# Altium schematic sheet PMIC1_iMX6.SchDoc
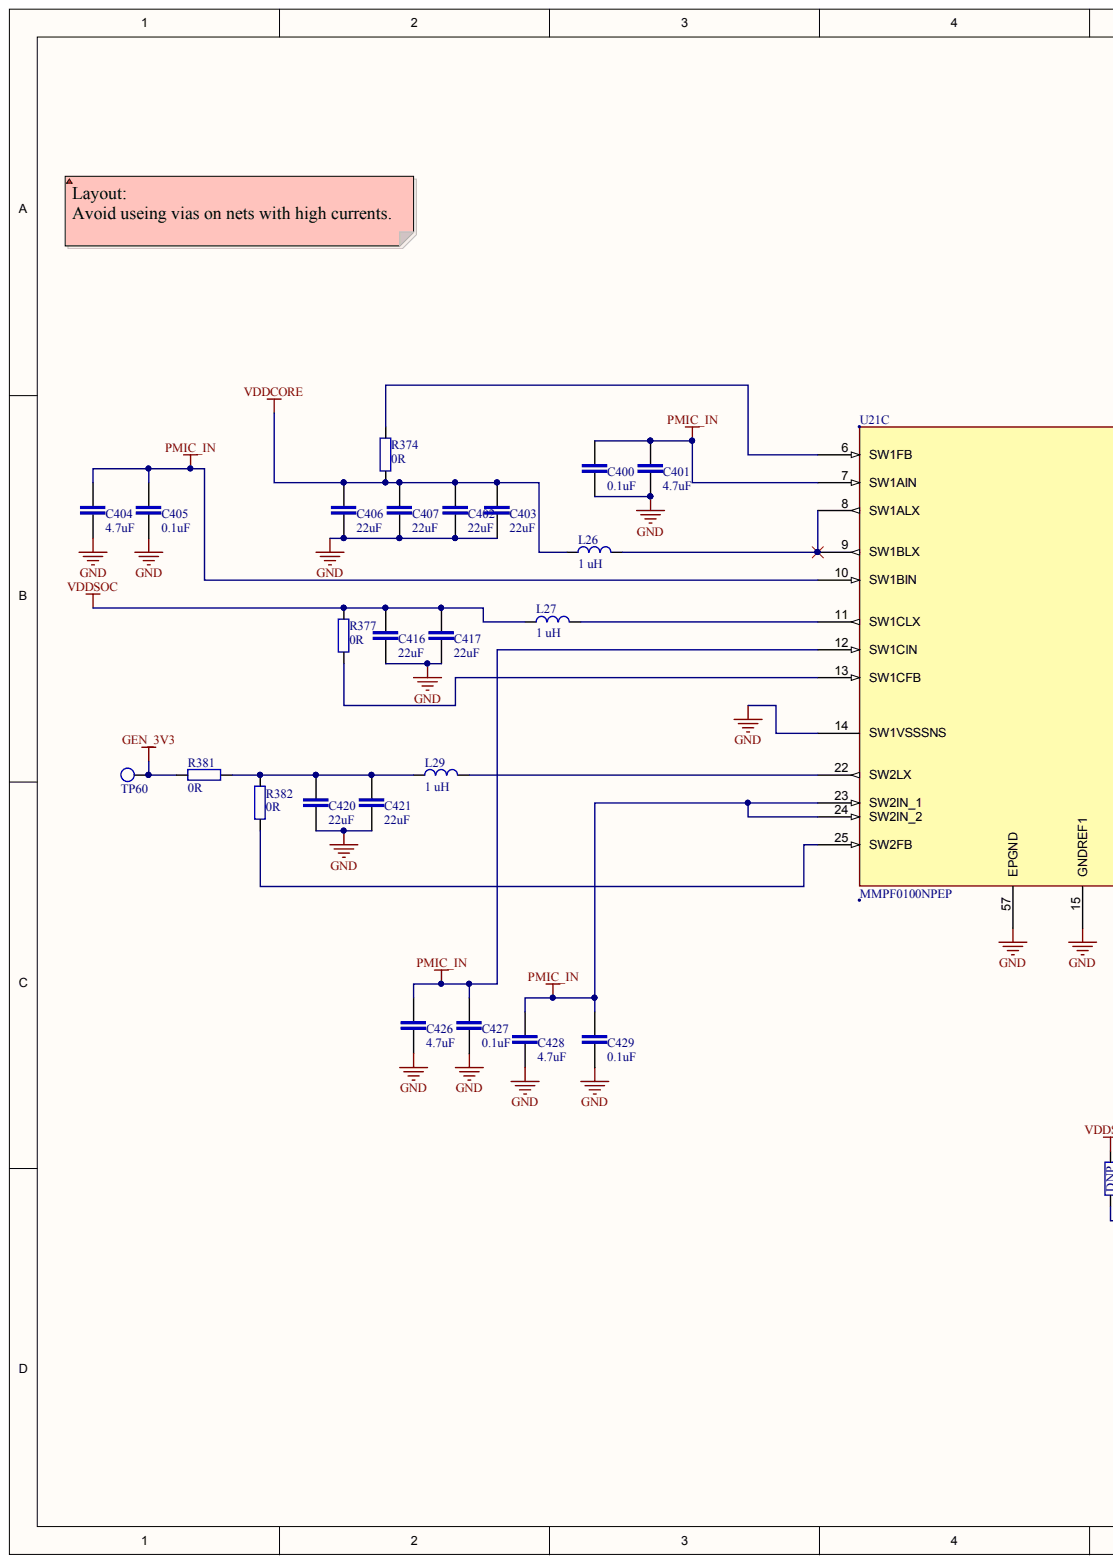
[diagram p0: PMIC1_iMX6.SchDoc, left]
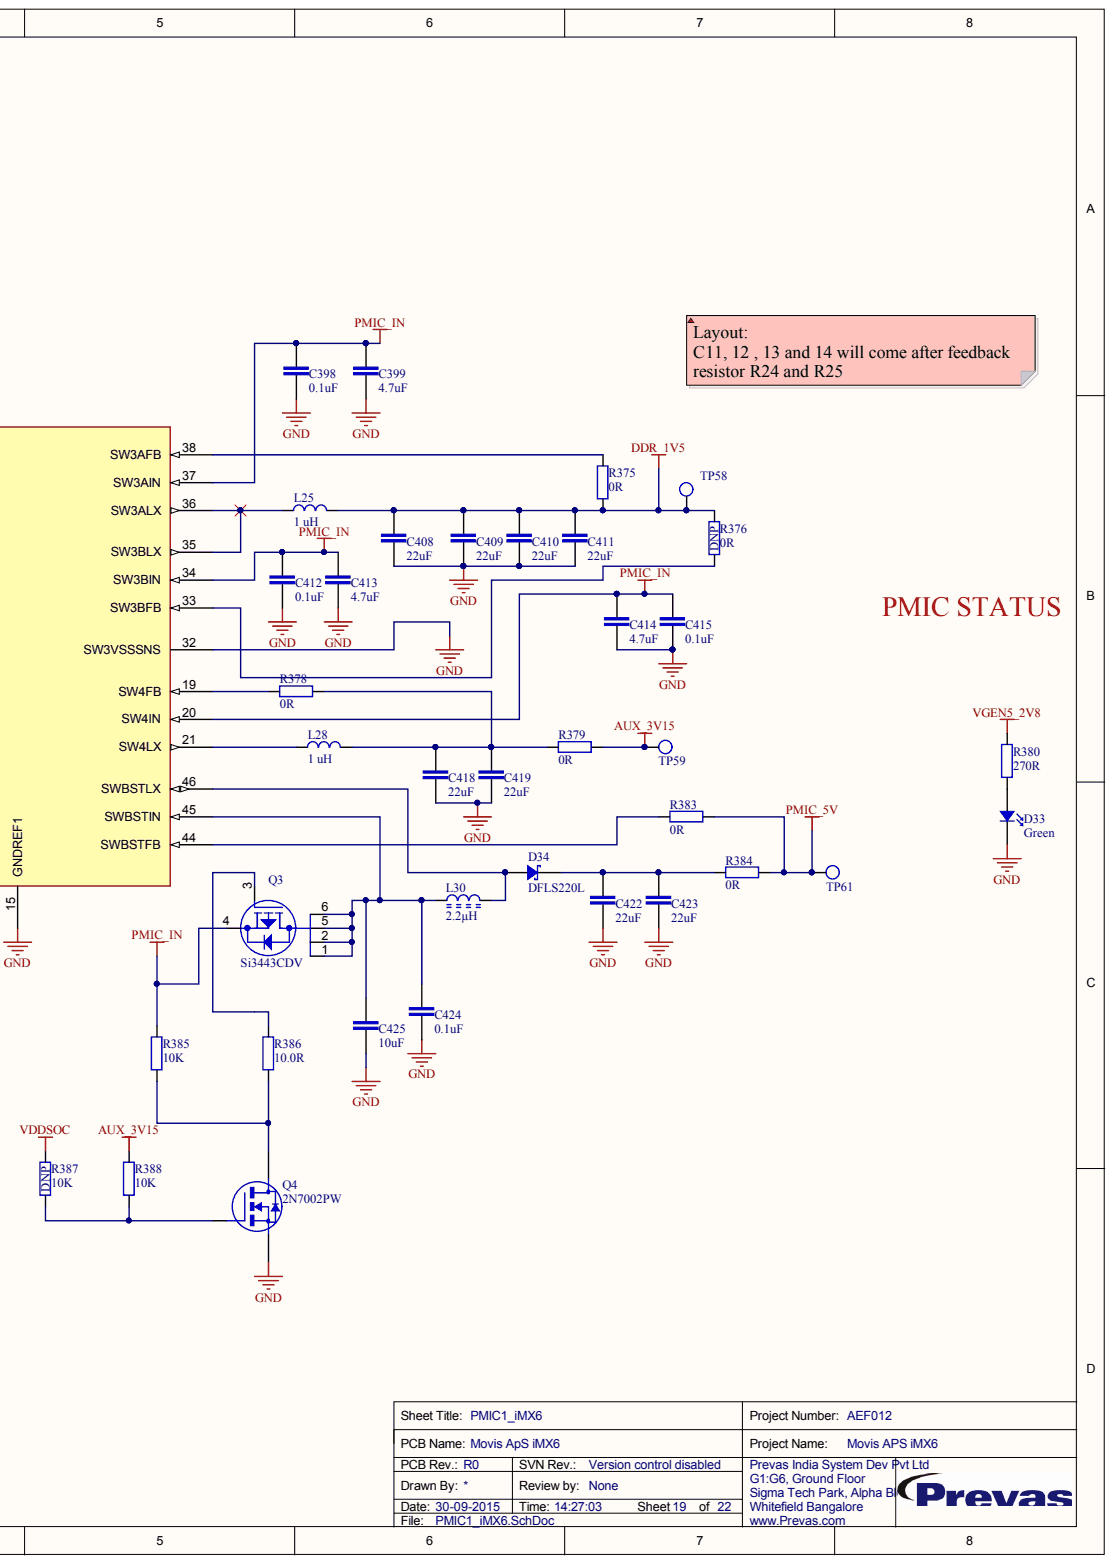
[diagram p1: PMIC1_iMX6.SchDoc, right]

## Parts
C398  0.1uF  [CAPC1005X55N]
C399  4.7uF  [CAPC1608X90N]
C400  0.1uF  [CAPC1005X55N]
C401  4.7uF  [CAPC1608X90N]
C402  22uF  [CAPC3216X180N]
C403  22uF  [CAPC3216X180N]
C404  4.7uF  [CAPC1608X90N]
C405  0.1uF  [CAPC1005X55N]
C406  22uF  [CAPC3216X180N]
C407  22uF  [CAPC3216X180N]
C408  22uF  [CAPC3216X180N]
C409  22uF  [CAPC3216X180N]
C410  22uF  [CAPC3216X180N]
C411  22uF  [CAPC3216X180N]
C412  0.1uF  [CAPC1005X55N]
C413  4.7uF  [CAPC1608X90N]
C414  4.7uF  [CAPC1608X90N]
C415  0.1uF  [CAPC1005X55N]
C416  22uF  [CAPC3216X180N]
C417  22uF  [CAPC3216X180N]
C418  22uF  [CAPC3216X180N]
C419  22uF  [CAPC3216X180N]
C420  22uF  [CAPC3216X180N]
C421  22uF  [CAPC3216X180N]
C422  22uF  [CAPC3216X180N]
C423  22uF  [CAPC3216X180N]
C424  0.1uF  [CAPC1005X55N]
C425  10uF  [CAPC1608X95N]
C426  4.7uF  [CAPC1608X90N]
C427  0.1uF  [CAPC1005X55N]
C428  4.7uF  [CAPC1608X90N]
C429  0.1uF  [CAPC1005X55N]
D33  Green  [LEDC1608X110N-G]
D34  DFLS220L  [SODFL3718X100N]
L25  1 uH  [IND_NRS4018T1R0NDGJ]
L26  1 uH  [IND_NRS4018T1R0NDGJ]
L27  1 uH  [IND_NRS4018T1R0NDGJ]
L28  1 uH  [IND_NRS4018T1R0NDGJ]
L29  1 uH  [IND_NRS4018T1R0NDGJ]
L30  2.2µH  [IND_VLF4012A]
Q3  Si3443CDV  [SOT95P280X110-6N]
Q4  2N7002PW  [SOT65P210X110-3N]
R374  0R  [RESC1608X55N]
R375  0R  [RESC1608X55N]
R376  0R  Fitted: DNP  [RESC1608X55N]
R377  0R  [RESC1608X55N]
R378  0R  [RESC1608X55N]
R379  0R  [RESC1608X55N]
R380  270R  [RESC1608X55N]
R381  0R  [RESC1608X55N]
R382  0R  [RESC1608X55N]
R383  0R  [RESC1608X55N]
R384  0R  [RESC1608X55N]
R385  10K  [RESC1608X55N]
R386  10.0R  [RESC3216X65N]
R387  10K  Fitted: DNP  [RESC1608X55N]
R388  10K  [RESC1608X55N]
TP58  Testpoint  [TP_SMD]  (value not drawn)  (no BOM)
TP59  Testpoint  [TP_SMD]  (value not drawn)  (no BOM)
TP60  Testpoint  [TP_SMD]  (value not drawn)  (no BOM)
TP61  Testpoint  [TP_SMD]  (value not drawn)  (no BOM)
U21  MMPF0100NPEP  [QFN50P800X800X90-57N]  (part C)

## Nets
AUX_3V15: R379.2 R388.2 TP59.1
DDR_1V5: C408.2 C409.2 C410.2 C411.2 L25.2 R375.1 R376.2 TP58.1
GEN_3V3: R381.1 TP60.1
GND: C398.1 C399.1 C400.1 C401.1 C402.1 C403.1 C404.1 C405.1 C406.1 C407.1 C408.1 C409.1 C410.1 C411.1 C412.1 C413.1 C414.1 C415.1 C416.1 C417.1 C418.1 C419.1 C420.1 C421.1 C422.2 C423.2 C424.1 C425.1 C426.1 C427.1 C428.1 C429.1 D33.C Q4.2 U21.14(SW1VSSSNS) U21.15(GNDREF1) U21.32(SW3VSSSNS) U21.57(EPGND)
PMIC_5V: R383.2 R384.2 TP61.1
PMIC_IN: C398.2 C399.2 C400.2 C401.2 C404.2 C405.2 C412.2 C413.2 C414.2 C415.2 C426.2 C427.2 C428.2 C429.2 Q3.4 R385.2 U21.7(SW1AIN) U21.10(SW1BIN) U21.12(SW1CIN) U21.20(SW4IN) U21.23(SW2IN_1) U21.24(SW2IN_2) U21.34(SW3BIN) U21.37(SW3AIN)
VDDCORE: C402.2 C403.2 C406.2 C407.2 L26.1 R374.1
VDDSOC: C416.2 C417.2 L27.1 R377.1 R387.2
VGEN5_2V8: R380.2
NetC418_2: C418.2 C419.2 L28.2 R378.2 R379.1
NetC420_2: C420.2 C421.2 L29.1 R381.2 R382.1
NetC422_1: C422.1 C423.1 D34.C R384.1
NetC424_2: C424.2 C425.2 L30.1 Q3.1 Q3.2 Q3.5 Q3.6 U21.45(SWBSTIN)
NetD33_A: D33.A R380.1
NetD34_A: D34.A L30.2 U21.46(SWBSTLX)
NetL25_1: L25.1 U21.35(SW3BLX) U21.36(SW3ALX)
NetL26_2: L26.2 U21.8(SW1ALX) U21.9(SW1BLX)
NetL27_2: L27.2 U21.11(SW1CLX)
NetL28_1: L28.1 U21.21(SW4LX)
NetL29_2: L29.2 U21.22(SW2LX)
NetQ3_3: Q3.3 R386.2
NetQ4_1: Q4.1 R387.1 R388.1
NetQ4_3: Q4.3 R385.1 R386.1
NetR374_2: R374.2 U21.6(SW1FB)
NetR375_2: R375.2 U21.38(SW3AFB)
NetR376_1: R376.1 U21.33(SW3BFB)
NetR377_2: R377.2 U21.13(SW1CFB)
NetR378_1: R378.1 U21.19(SW4FB)
NetR382_2: R382.2 U21.25(SW2FB)
NetR383_1: R383.1 U21.44(SWBSTFB)

## Library data of the parts
C398 C400 C405 C412 C415 C424 C427 C429 = INNISI-73: CAP CER 0.1UF 50V 10% X5R 0402; ref_part_type: /capacitor/non_polarized/ceramic/; source_manufacturer_1: TDK Corporation; source_manufacturer_1_pn: C1005X5R1H104K050BB; cae_altium_pcb_status: Prototype; Database Table Name: altium_default; db_rev: 7569; elec_temp_range: -55 to +85 C; phy_height: 0.55mm; phy_mounting: SMD; phy_packaging_type: 0402; ref_datasheet: INNISI-71; cae_altium_sch_status: Prototype; Component Kind: Standard; ComponentLink1Description: Datasheet; ComponentLink1URL: https://cop201.prevas.dk/viewvc/datasheet/INNISI-71.pdf?root=hw_components&view=co; db_created: 2015-08-03 03:34:59.0; db_last_updated: 03-08-2015 03:35:01; db_local_id: INNISI-73; db_status: Prototype; elec_tolerance: ±10%; elec_value: 0.1uF; elec_voltage_rating: 50V; phy_material: X5R; ref_keyword: CAP CER 0.1UF 50V 10% X5R 0402; Library Name: altium_mysql.dblib
C399 C401 C404 C413 C414 C426 C428 = INNISI-102: Ceramic capacitor X5R 0603; ref_part_type: /capacitor/non_polarized/ceramic/; source_manufacturer_1: TAIYO YUDEN; source_manufacturer_1_pn: EMK107ABJ475KA-T; cae_altium_pcb_status: Prototype; Database Table Name: altium_default; db_rev: 7663; elec_temp_range: -55 to +85; elec_tolerance: 10%; elec_voltage_rating: 16V; phy_height: 0.90mm; phy_mounting: SMD; phy_packaging_type: 0603; ref_keyword: Multilayer chip capacitor; cae_altium_sch_status: Prototype; Component Kind: Standard; ComponentLink1Description: Datasheet; db_created: 2015-08-04 09:58:51.0; db_last_updated: 04-08-2015 09:58:53; db_local_id: INNISI-102; db_status: Prototype; elec_value: 4.7uF; phy_material: X5R; Library Name: altium_mysql.dblib
C402 C403 C406-C411 C416-C423 = INNISI-77: CAP CER 22UF 25V 10% X5R 1206; ref_part_type: /capacitor/non_polarized/ceramic/; source_manufacturer_1: Murata Electronics; source_manufacturer_1_pn: GRM31CR61E226KE15L; cae_altium_pcb_status: Prototype; Database Table Name: altium_default; db_rev: 7573; elec_temp_range: -55 to +85 C; phy_height: 1.8mm; phy_mounting: SMD; phy_packaging_type: 1206; ref_datasheet: INNISI-74; ref_keyword: Multilayer chip capacitor; cae_altium_sch_status: Prototype; Component Kind: Standard; ComponentLink1Description: Datasheet; ComponentLink1URL: https://cop201.prevas.dk/viewvc/datasheet/INNISI-74.pdf?root=hw_components&view=co; db_created: 2015-08-03 04:56:21.0; db_last_updated: 03-08-2015 04:56:22; db_local_id: INNISI-77; db_status: Prototype; elec_tolerance: 10%; elec_value: 22uF; elec_voltage_rating: 25V; phy_material: X5R; Library Name: altium_mysql.dblib
C425 = innisi-78: CAP CER 10UF 16V 10% X5R 0603; ref_part_type: /capacitor/non_polarized/ceramic/; source_manufacturer_1: Murata; source_manufacturer_1_pn: GRM188R61C106KAALD; cae_altium_pcb_status: Prototype; Database Table Name: altium_default; db_rev: 7574; elec_temp_range: -55 to +85C; phy_height: 0.95mm; phy_mounting: SMD; phy_packaging_type: 0603; ref_datasheet: INNISI-75; ref_keyword: Ceramic capacitor X5R 0603; cae_altium_sch_status: Prototype; Component Kind: Standard; ComponentLink1Description: Datasheet; ComponentLink1URL: https://cop201.prevas.dk/viewvc/datasheet/INNISI-75.pdf?root=hw_components&view=co; db_created: 2015-08-03 05:08:42.0; db_last_updated: 03-08-2015 05:08:44; db_local_id: INNISI-78; db_status: Prototype; elec_tolerance: 10%; elec_value: 10uF; elec_voltage_rating: 16V; phy_material: X5R; Library Name: altium_mysql.dblib
D33 = NIMA-41: Green SMD LED; ref_part_type: /optical/emmision/diode/; source_manufacturer_1: Kingbright; cae_altium_pcb_status: Prototype; Database Table Name: altium_default; db_rev: 1020; elec_current_rating: 20mA; elec_power_rating: 75mW; elec_temp_range: -40 to 85; elec_voltage_rating: 2.1V; phy_height: 1.1mm; phy_packaging_type: 0603; ref_datasheet: NIMA-34; ref_keyword: KPT-1608MGC; cae_altium_sch_status: Prototype; Component Kind: Standard; ComponentLink1Description: Datasheet; ComponentLink1URL: https://cop201.prevas.dk/viewvc/datasheet/NIMA-34.pdf?root=hw_components&view=co; db_created: 2009-03-23 21:34:44; db_last_updated: 24-03-2009 16:39:49; db_local_id: NIMA-41; db_status: Prototype; elec_value: Green; Mounted: Yes; phy_mounting: SMD; source_manufacturer_1_pn: KPT-1608MGC; Library Name: altium_mysql.dblib
D34 = NIMA-225: Schottky Diode Rectifier, 2A; ref_part_type: /diode/schottky/; source_manufacturer_1: Diodes Inc; source_manufacturer_1_pn: DFLS220L-7; cae_altium_pcb_status: Prototype; Database Table Name: altium_default; db_rev: 2763; elec_temp_range: -55 to +125°C; elec_voltage_rating: 20V; phy_height: 1.0mm; phy_mounting: SMD; phy_packaging_type: PowerDI123; ref_datasheet: NIMA-165; ref_keyword: Schottky Diode SMD; cae_altium_sch_status: Prototype; Component Kind: Standard; ComponentLink1Description: Datasheet; ComponentLink1URL: https://cop201.prevas.dk/viewvc/datasheet/NIMA-165.pdf?root=hw_components&view=co; db_created: 2010-09-29 00:35:27.0; db_last_updated: 11-10-2010 13:07:30; db_local_id: NIMA-225; db_status: Prototype; elec_current_rating: 2A; elec_value: DFLS220L; Library Name: altium_mysql.dblib
L25-L29 = INRAAN-234: SMD power Inductor; ref_part_type: /inductor/power/; source_manufacturer_1: Taiyo Yuden; source_manufacturer_1_pn: NRS4018T1R0NDGJ; cae_altium_pcb_status: Prototype; Database Table Name: altium_default; db_rev: 6938; elec_current_rating: 4A; elec_frequency_rating: 90 MHz; elec_temp_range: - 25 C to + 125 C; phy_height: 1.8mm; ref_datasheet: INRAAN-219; cae_altium_sch_status: Prototype; Component Kind: Standard; ComponentLink1Description: Datasheet; ComponentLink1URL: https://cop201.prevas.dk/viewvc/datasheet/INRAAN-219.pdf?root=hw_components&view=co; db_created: 2014-12-02 06:32:07.0; db_last_updated: 12/2/2014 6:32:09 AM; db_local_id: INRAAN-234; db_status: Prototype; elec_value: 1 uH; phy_mounting: SMD; ref_keyword: Power Inductor; Library Name: altium_mysql.dblib
L30 = GEPW-166: Shielded SMD choke coil; ref_part_type: /inductor/power/; source_manufacturer_1: TDK; source_manufacturer_1_pn: VLF4012AT-2R2M1R5; cae_altium_pcb_status: Prototype; Database Table Name: altium_default; db_rev: 3367; elec_current_rating: 1.5A; elec_temp_range: -40 to 105; elec_tolerance: +/-20%; phy_height: 1.2mm; phy_material: Ferrite; ref_datasheet: GEPW-144; ref_keyword: Power inductor VLF Series; cae_altium_sch_status: Prototype; Component Kind: Standard; ComponentLink1Description: Datasheet; ComponentLink1URL: https://cop201.prevas.dk/viewvc/datasheet/GEPW-144.pdf?root=hw_components&view=co; db_created: 2011-04-27 14:44:28.0; db_last_updated: 27-04-2011 14:44:28; db_local_id: GEPW-166; db_status: Prototype; elec_value: 2.2µH; phy_mounting: SMD; Library Name: altium_mysql.dblib
Q3 = INRAAN-236: P-Channel 20 V (D-S) MOSFET; ref_part_type: /transistor/mosfet/p_channel/; source_manufacturer_1: Vishay / Siliconix; cae_altium_pcb_status: Prototype; Database Table Name: altium_default; db_rev: 6941; elec_temp_range: -55C to 150C; elec_voltage_rating: 2W; phy_height: 1.1mm; phy_mounting: SMD; phy_packaging_type: TSOP-6; ref_datasheet: INRAAN-221; ref_keyword: P-Channel MOSFET; cae_altium_sch_status: Prototype; Component Kind: Standard; ComponentLink1Description: Datasheet; ComponentLink1URL: https://cop201.prevas.dk/viewvc/datasheet/INRAAN-221.pdf?root=hw_components&view=co; db_created: 2014-12-02 08:39:14.0; db_last_updated: 12/2/2014 8:43:44 AM; db_local_id: INRAAN-236; db_status: Prototype; elec_value: Si3443CDV; source_manufacturer_1_pn: Si3443CDV; Library Name: altium_mysql.dblib
Q4 = GEPW-188: Digital FET, N-channel; ref_part_type: /transistor/mosfet/n_channel/; source_manufacturer_1: NXP Semiconductors; cae_altium_pcb_status: Prototype; Database Table Name: altium_default; db_rev: 3462; elec_current_rating: 0.31A; elec_power_rating: 0.31W; elec_temp_range: -55 to 155; elec_voltage_rating: 60V; phy_height: 1.10mm; phy_mounting: SMD; phy_packaging_type: SOT-23; ref_datasheet: GEPW-166; cae_altium_sch_status: Prototype; Component Kind: Standard; ComponentLink1Description: Datasheet; ComponentLink1URL: https://cop201.prevas.dk/viewvc/datasheet/GEPW-166.pdf?root=hw_components&view=co; db_created: 2011-05-16 15:26:43.0; db_last_updated: 16-05-2011 15:27:53; db_local_id: GEPW-188; db_status: Prototype; elec_value: 2N7002PW; ref_keyword: Digital FET, N-channel; source_manufacturer_1_pn: 2N7002PW; Library Name: altium_mysql.dblib
R374-R379 R381-R384 = INVIHA-4: RES SMD 0.0 OHM JUMPER 1/10W; ref_part_type: /resistor/fixed/; source_manufacturer_1: Panasonic Electronic Components; source_manufacturer_1_pn: ERJ-3GEY0R00V; cae_altium_pcb_status: Prototype; Database Table Name: altium_default; db_rev: 7580; elec_power_rating: 0.1W; elec_temp_range: -55 to +155° C; phy_height: 0.55mm; phy_packaging_type: 0603; ref_datasheet: INVIHA-3; ref_keyword: Chip Resistor; cae_altium_sch_status: Prototype; Component Kind: Standard; ComponentLink1Description: Datasheet; ComponentLink1URL: https://cop201.prevas.dk/viewvc/datasheet/INVIHA-3.pdf?root=hw_components&view=co; db_created: 2015-08-03 05:59:19.0; db_last_updated: 03-08-2015 5:59:21 AM; db_local_id: INVIHA-4; db_status: Prototype; elec_value: 0R; phy_mounting: SMD; Library Name: altium_mysql.dblib
R380 = INVIHA-30: RES SMD 270 OHM 1% 1/10W 0603; ref_part_type: /resistor/fixed/; source_manufacturer_1: Yageo; source_manufacturer_1_pn: RC0603FR-07270RL; cae_altium_pcb_status: Prototype; Database Table Name: altium_default; db_rev: 7621; elec_power_rating: 0.1W; elec_temp_range: -55 to +155° C; phy_height: 0.55mm; phy_packaging_type: 0603; ref_datasheet: INVIHA-2; ref_keyword: Chip Resistor; cae_altium_sch_status: Prototype; Component Kind: Standard; ComponentLink1Description: Datasheet; ComponentLink1URL: https://cop201.prevas.dk/viewvc/datasheet/INVIHA-2.pdf?root=hw_components&view=co; db_created: 2015-08-03 08:44:31.0; db_last_updated: 03-08-2015 8:44:33 AM; db_local_id: INVIHA-30; db_status: Prototype; elec_tolerance: 1%; elec_value: 270R; phy_mounting: SMD; Library Name: altium_mysql.dblib
R385 R387 R388 = INVIHA-3: RES SMD 10K OHM 1% 1/10W 0603; ref_part_type: /resistor/fixed/; source_manufacturer_1: Yageo; source_manufacturer_1_pn: RC0603FR-0710KL; cae_altium_pcb_status: Prototype; Database Table Name: altium_default; db_rev: 7575; elec_power_rating: 0.1W; elec_temp_range: -55 to +155° C; phy_height: 0.55mm; phy_packaging_type: 0603; ref_datasheet: INVIHA-2; ref_keyword: Chip Resistor; cae_altium_sch_status: Prototype; Component Kind: Standard; ComponentLink1Description: Datasheet; ComponentLink1URL: https://cop201.prevas.dk/viewvc/datasheet/INVIHA-2.pdf?root=hw_components&view=co; db_created: 2015-08-03 05:39:03.0; db_last_updated: 03-08-2015 5:39:05 AM; db_local_id: INVIHA-3; db_status: Prototype; elec_tolerance: 1%; elec_value: 10K; phy_mounting: SMD; Library Name: altium_mysql.dblib
R386 = JEPE-132: Resistor 1206 General Purpose; ref_part_type: /resistor/fixed/; source_manufacturer_1: Phycomp; source_manufacturer_1_pn: RC1206FR-**10R; cae_altium_pcb_status: Prototype; Database Table Name: altium_default; db_rev: 2103; elec_current_rating: 2A; elec_power_rating: 0.25W; elec_temp_range: -55 to +155; elec_tolerance: 1%; elec_voltage_rating: 200V; phy_height: 0.65mm; phy_material: Thick Film; phy_mounting: SMD; phy_packaging_type: 1206; ref_datasheet: JEPE-90; ref_keyword: Thick Film Chip Resistor; cae_altium_sch_status: Prototype; Component Kind: Standard; ComponentLink1Description: Datasheet; ComponentLink1URL: https://cop201.prevas.dk/viewvc/datasheet/JEPE-90.pdf?root=hw_components&view=co; db_created: 2008-08-22 10:27:28; db_last_updated: 26-04-2010 10:43:50; db_local_id: JEPE-132; db_status: Prototype; elec_value: 10.0R; Library Name: altium_mysql.dblib
TP58-TP61 = JEPE-114: Test Point SMD; ref_part_type: /pcb_item/; cae_altium_pcb_status: Prototype; Database Table Name: altium_default; db_rev: 3281; cae_altium_sch_status: Prototype; ComponentLink1Description: Datasheet; db_created: 2008-08-18 11:39:42; db_last_updated: 23-03-2011 14:14:06; db_local_id: JEPE-114; db_status: Prototype; elec_value: Test Point; phy_mounting: SMD; ref_keyword: Test  Point; Library Name: altium_mysql.dblib
U21 = INRAAN-3: 14 Channel Configurable Power Management IC; ref_part_type: /integrated/analog/power/supply/distribution/; source_manufacturer_1: Freescale; AGILE_PN: 315-79407; CAD_APPROVED: Yes; cae_altium_pcb_status: Prototype; Database Table Name: altium_default; db_rev: 6250; elec_current_rating: 0 to 10mA; elec_frequency_rating: 4MHz; elec_temp_range: -40 to 125; elec_value: PF0100; elec_voltage_rating: 2.8 to 4.5V; MFG_NAME01: FREESCALE SEMICONDUCTOR; phy_height: 0.9; phy_mounting: SMD; phy_packaging_type: QFN56; ref_keyword: PMIC; SPLIT_INST: TRUE; SWAP_INFO: (S1+S2+S3); cae_altium_sch_status: Prototype; CLASS: IC; Component Kind: Standard; ComponentLink1Description: Datasheet; ComponentLink1URL: https://cop201.prevas.dk/viewvc/datasheet/INRAAN-3.pdf?root=hw_components&view=co; db_created: 2013-10-21 10:26:23.0; db_last_updated: 27-12-2013 12:12:58; db_local_id: INRAAN-3; db_status: Prototype; HEIGHT: 0.9MM; MFG_PN01: MMPF0100NPEP; ref_datasheet: INRAAN-3; ROHS: Yes; source_manufacturer_1_pn: MMPF0100NPEP; Library Name: altium_mysql.dblib
